# Altium schematic sheet Gameboy Link.SchDoc
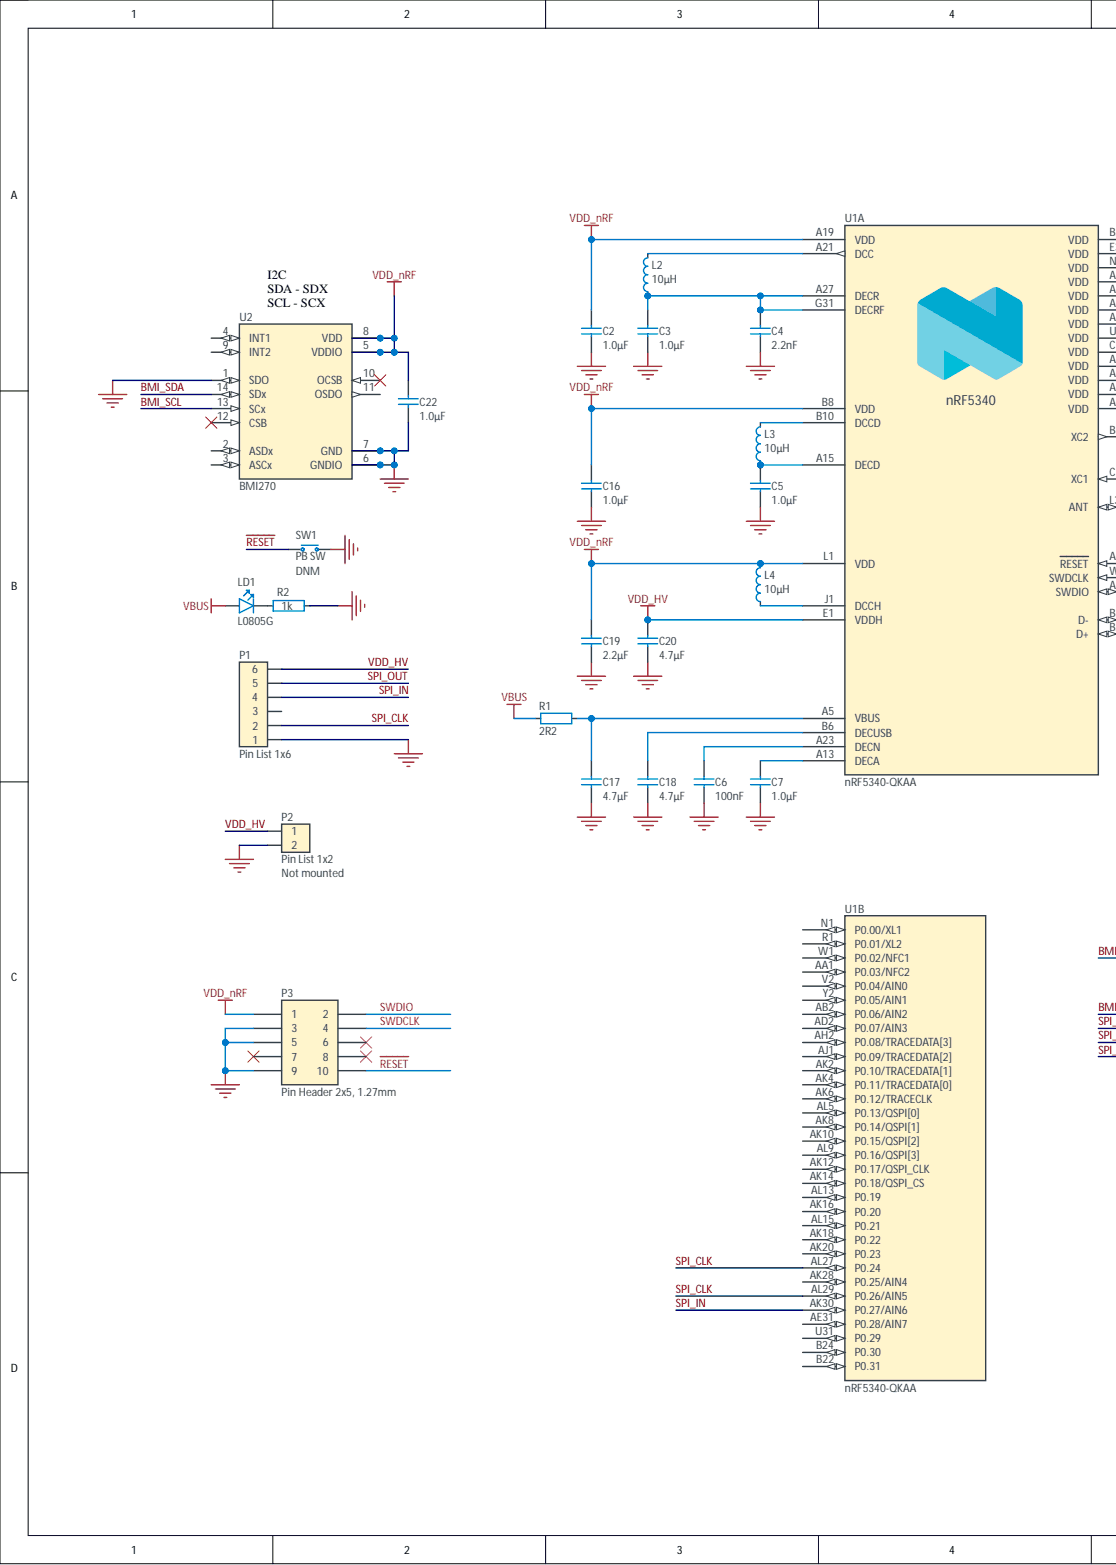
[diagram p0: Gameboy Link.SchDoc, left]
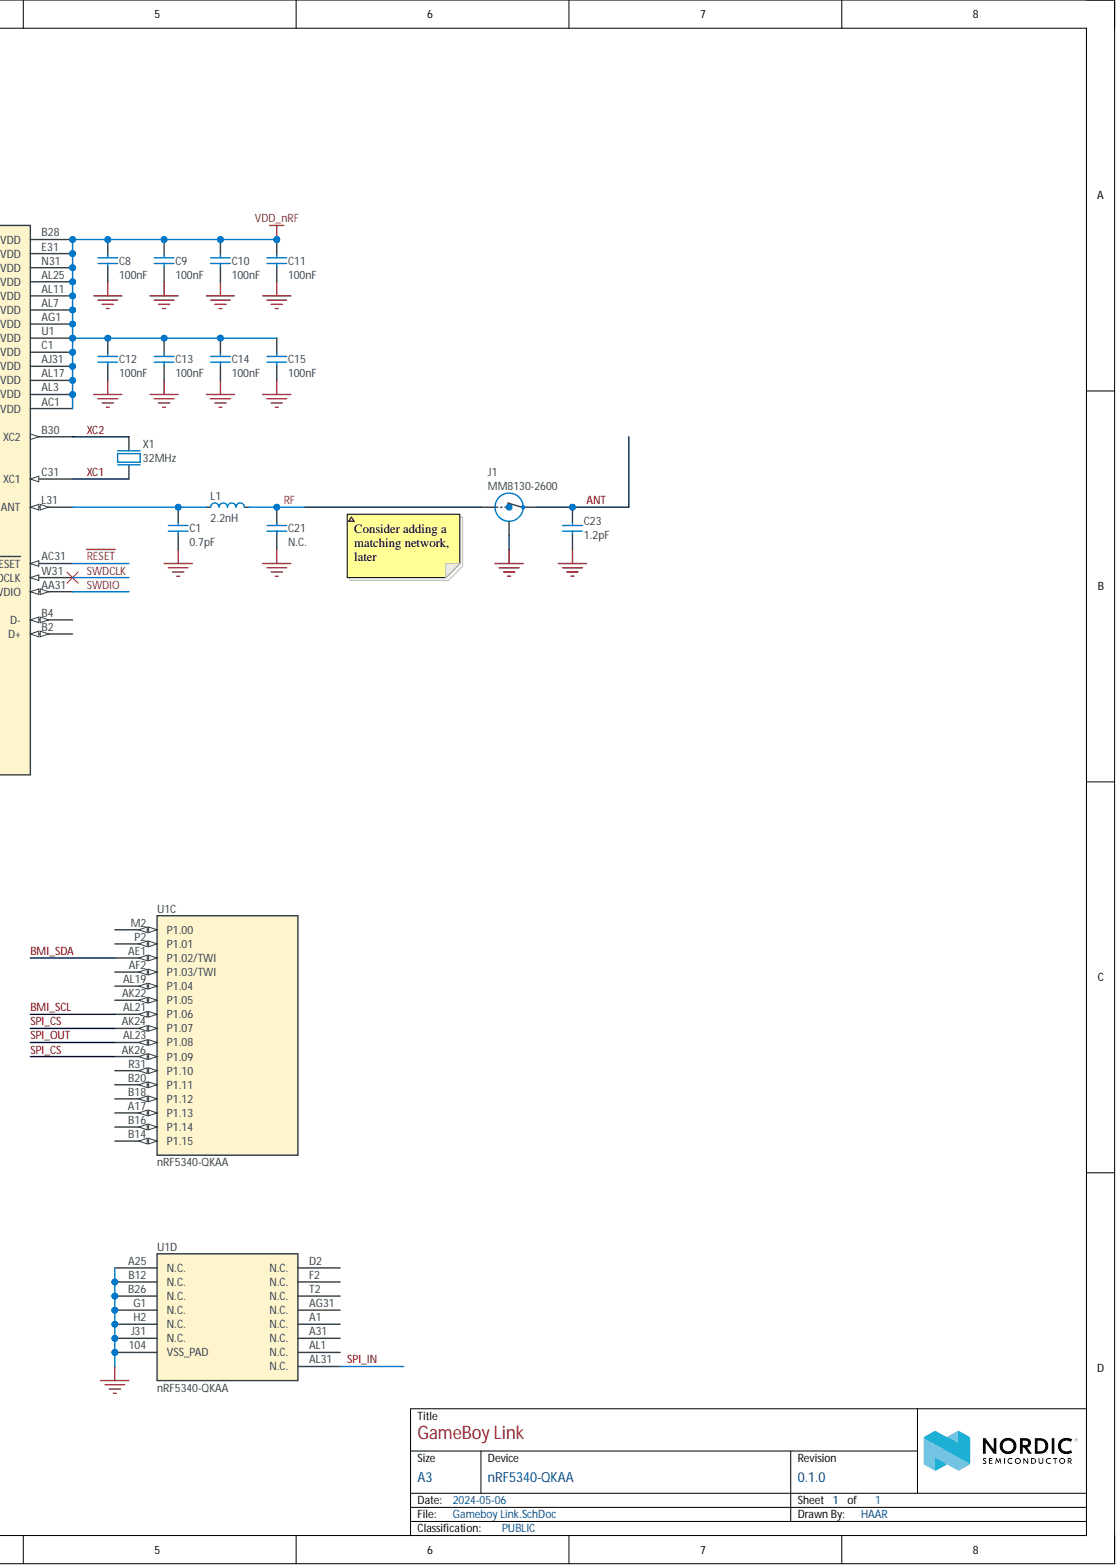
[diagram p1: Gameboy Link.SchDoc, right]

## Parts
C1  0.7pF  [CAPC0603X03L_C]
C2  1.0µF  [CAPC1005X04L]
C3  1.0µF  [CAPC1005X04L]
C4  2.2nF  [CAPC0603X03L_C]
C5  1.0µF  [CAPC1005X04L]
C6  100nF  [CAPC0603X03L_C]
C7  1.0µF  [CAPC1005X04L]
C8  100nF  [CAPC0603X03L_C]
C9  100nF  [CAPC0603X03L_C]
C10  100nF  [CAPC0603X03L_C]
C11  100nF  [CAPC0603X03L_C]
C12  100nF  [CAPC0603X03L_C]
C13  100nF  [CAPC0603X03L_C]
C14  100nF  [CAPC0603X03L_C]
C15  100nF  [CAPC0603X03L_C]
C16  1.0µF  [CAPC1005X04L]
C17  4.7µF  [CAPC1608X06L]
C18  4.7µF  [CAPC1608X06L]
C19  2.2µF  [CAPC1608X06L]
C20  4.7µF  [CAPC1608X06L]
C21  N.C.  [CAPC0603X03L_C_NC]
C22  1.0µF  [CAPC1005X04L]
C23  1.2pF  [CAPC1005X04L]
J1  MM8130-2600  [COAXIAL-SWF]
L1  2.2nH  [INDC0603X03L_C]
L2  10µH  [INDC1608X06L]
L3  10µH  [INDC1608X06L]
L4  10µH  [INDC1608X06L]
LD1  L0805G  [LED_0805_G]
P1  Pin List 1x6  [LIST_1x6]
P2  Pin List 1x2  Assembly: Not mounted  [LIST_1x2-NC]
P3  Pin Header 2x5, 1.27mm  [HDR_2x5-SMD-1.27mm-K]
R1  2R2  [RESC0603X03L_C]
R2  1k  [RESC1005X04L]
SW1  PB SW  Assembly: DNM  [Switch-PB-6.2x6.3mm-SMD]
U1  nRF5340-QKAA  [AQFN40P700X700X85_HS-99N]  (parts A,B,C,D)
U2  BMI270  [BMI270]
X1  32MHz  [BT-XTAL_2016]

## Nets
GND: C1.1 C2.2 C3.1 C4.2 C5.1 C6.2 C7.1 C8.2 C9.2 C10.2 C11.2 C12.2 C13.2 C14.2 C15.2 C16.1 C17.2 C18.2 C19.2 C20.2 C21.1 C22.2 C23.2 J1.2 P1.1 P2.2 P3.3 P3.5 P3.9 R2.1 SW1.1 U1.104(VSS_PAD) U1.A25(N.C.) U1.B12(N.C.) U1.B26(N.C.) U1.G1(N.C.) U1.H2(N.C.) U1.J31(N.C.) U2.1(SDO) U2.6(GNDIO) U2.7(GND) X1.2 X1.4
VBUS: LD1.2 R1.1
VDD_nRF: C2.1 C8.1 C9.1 C10.1 C11.1 C12.1 C13.1 C14.1 C15.1 C16.2 C19.1 C22.1 L4.2 P3.1 U1.A19(VDD) U1.AC1(VDD) U1.AG1(VDD) U1.AJ31(VDD) U1.AL3(VDD) U1.AL7(VDD) U1.AL11(VDD) U1.AL17(VDD) U1.AL25(VDD) U1.B8(VDD) U1.B28(VDD) U1.C1(VDD) U1.E31(VDD) U1.L1(VDD) U1.N31(VDD) U1.U1(VDD) U2.5(VDDIO) U2.8(VDD)
ANT: C23.1 J1.3
BMI_SCL: U1.AL21(P1.06) U2.13(SCx)
BMI_SDA: U1.AE1(P1.02/TWI) U2.14(SDx)
~{RESET}: P3.10 SW1.2 U1.AC31(RESET)
RF: C21.2 J1.1 L1.1
SPI_CLK: P1.2 U1.AL27(P0.24) U1.AL29(P0.26/AIN5)
SPI_CS: U1.AK24(P1.07) U1.AK26(P1.09)
SPI_IN: P1.4 U1.AK30(P0.27/AIN6) U1.AL31(N.C.)
SPI_OUT: P1.5 U1.AL23(P1.08)
SWDCLK: P3.4 U1.W31(SWDCLK)
SWDIO: P3.2 U1.AA31(SWDIO)
VDD_HV: C20.1 P1.6 P2.1 U1.E1(VDDH)
XC1: U1.C31(XC1) X1.1
XC2: U1.B30(XC2) X1.3
NetC1_2: C1.2 L1.2 U1.L31(ANT)
NetC3_2: C3.2 C4.1 L2.1 U1.A27(DECR) U1.G31(DECRF)
NetC5_2: C5.2 L3.1 U1.A15(DECD)
NetC6_1: C6.1 U1.A23(DECN)
NetC7_2: C7.2 U1.A13(DECA)
NetC17_1: C17.1 R1.2 U1.A5(VBUS)
NetC18_1: C18.1 U1.B6(DECUSB)
NetL2_2: L2.2 U1.A21(DCC)
NetL3_2: L3.2 U1.B10(DCCD)
NetL4_1: L4.1 U1.J1(DCCH)
NetLD1_1: LD1.1 R2.2

Not connected: P1 3; P3 6 7 8; U1 A1(N.C.) A17(P1.13) A31(N.C.) AA1(P0.03/NFC2) AB2(P0.06/AIN2) AD2(P0.07/AIN3) AE31(P0.28/AIN7) AF2(P1.03/TWI) AG31(N.C.) AH2(P0.08/TRACEDATA[3]) AJ1(P0.09/TRACEDATA[2]) AK2(P0.10/TRACEDATA[1]) AK4(P0.11/TRACEDATA[0]) AK6(P0.12/TRACECLK) AK8(P0.14/QSPI[1]) AK10(P0.15/QSPI[2]) AK12(P0.17/QSPI_CLK) AK14(P0.18/QSPI_CS) AK16(P0.20) AK18(P0.22) AK20(P0.23) AK22(P1.05) AK28(P0.25/AIN4) AL1(N.C.) AL5(P0.13/QSPI[0]) AL9(P0.16/QSPI[3]) AL13(P0.19) AL15(P0.21) AL19(P1.04) B2(D+) B4(D-) B14(P1.15) B16(P1.14) B18(P1.12) B20(P1.11) B22(P0.31) B24(P0.30) D2(N.C.) F2(N.C.) M2(P1.00) N1(P0.00/XL1) P2(P1.01) R1(P0.01/XL2) R31(P1.10) T2(N.C.) U31(P0.29) V2(P0.04/AIN0) W1(P0.02/NFC1) Y2(P0.05/AIN1); U2 2(ASDx) 3(ASCx) 4(INT1) 9(INT2) 10(OCSB) 11(OSDO) 12(CSB)

## Library data of the parts
C1 = C0201-0.7p-HQ-Murata: Capacitor, Ceramic, NP0, ±0.1pF, 25V; Manufacturer: Murata; Manufacturer Part Number: GJM0335C1ER70BB01D; Part number NEMS: 100200441; Part number Norautron: 26470001; Artikkelnr Noca: N.A.; Component Status: Active; Database Table Name: Parts$; Pad Count: 2; Component Class: Capacitors; Component Kind: Standard; ComponentLink1Description: Datasheet; ComponentLink1URL: https://search.murata.co.jp/Ceramy/image/img/A01X/G101/ENG/GJM0335C1ER70BB01-01.pdf; ComponentLink2Description: Supplier link; ComponentLink2URL: https://www.murata.com/en-eu/products/productdetail?partno=GJM0335C1ER70BB01%23; Footprint Doc: 0201; HelpURL: https://www.digikey.no/product-detail/en/murata-electronics/GJM0335C1ER70BB01D/490-8076-1-ND/4380362; LatestRevisionDate: 2020-10-13; Library Name: AltiumLib.DbLib; Note: 25V; Part Number: C0201-0.7p-HQ-Murata; Part number IPS: N.A.; PartId: 100200441; Published: 2020-03-05; Supplier 1: Digi-Key; Supplier 2: N.A.; Supplier Part Number 1: 490-8076-1-ND; Supplier Part Number 2: N.A.; Value: 0.7pF; Author: rsk
C2 C3 C5 C7 C16 C22 = C0402-1µ0-10V-X7S-GRM155C71A105KE11: Capacitor, Ceramic, 1.0µF 10V X7S 0402,±10%; Manufacturer: Murata; Part number NEMS: 100200497; Artikkelnr Noca: N.A.; Component Status: Active; Database Table Name: Parts$; Pad Count: 2; Component Class: Capacitors; Component Kind: Standard; ComponentLink1Description: Datasheet; ComponentLink1URL: https://www.murata.com/en-sg/products/productdetail?partno=GRM155C71A105KE11%23; ComponentLink2Description: Supplier link; ComponentLink2URL: https://www.digikey.com/en/products/detail/murata-electronics/GRM155C71A105KE11D/6606086; Footprint Doc: 0402; HelpURL: N.A.; LatestRevisionDate: 2021-12-09; Library Name: AltiumLib.DbLib; Manufacturer Part Number: GRM155C71A105KE11; Note: 10V; Part Number: C0402-1µ0-10V-X7S-GRM155C71A105KE11; Part number IPS: N.A.; Part number Norautron: N.A.; PartId: 100200497; Published: 2021-12-09; Supplier 1: Mouser; Supplier 2: Digi-Key; Supplier Part Number 1: 81-GRM155C71A105KE1J; Supplier Part Number 2: 490-14457-1-ND; Value: 1.0µF; Author: prbu
C4 = C0201-2n2: Capacitor, X7R, ±10%, 10V; Part number IPS: 00000001778; Part number NEMS: 100200060; Part number Norautron: 26470002; Artikkelnr Noca: N.A.; Component Status: Active; Database Table Name: Parts$; Pad Count: 2; Component Class: Capacitors; Component Kind: Standard; ComponentLink1Description: Datasheet; ComponentLink1URL: N.A.; ComponentLink2Description: Supplier link; ComponentLink2URL: N.A.; Footprint Doc: 0201; HelpURL: N.A.; LatestRevisionDate: 2014-11-13; Library Name: AltiumLib.DbLib; Manufacturer: N.A.; Manufacturer Part Number: N.A.; Note: 10V; Part Number: C0201-2n2; PartId: 100200060; Published: 2014-11-13; Supplier 1: N.A.; Supplier 2: N.A.; Supplier Part Number 1: N.A.; Supplier Part Number 2: N.A.; Value: 2.2nF; Author: rsk
C6 C8-C15 = C0201-100n-16V-X7S: Capacitor, X7S, 16V; Manufacturer: Murata; Manufacturer Part Number: GRM033C71C104KE14D; Part number NEMS: 100200403; Artikkelnr Noca: N.A.; Component Status: Active; Database Table Name: Parts$; Pad Count: 2; Component Class: Capacitors; Component Kind: Standard; ComponentLink1Description: Datasheet; ComponentLink1URL: http://search.murata.co.jp/Ceramy/image/img/A01X/G101/ENG/GRM033C71C104KE14-01.pdf; ComponentLink2Description: Supplier link; ComponentLink2URL: N.A.; Footprint Doc: 0201; HelpURL: N.A.; LatestRevisionDate: 2020-10-13; Library Name: AltiumLib.DbLib; Note: 16V; Part Number: C0201-100n-16V-X7S; Part number IPS: N.A.; Part number Norautron: N.A.; PartId: 100200403; Published: 2018-05-07; Supplier 1: Digi-Key; Supplier 2: N.A.; Supplier Part Number 1: 490-10387-1-ND; Supplier Part Number 2: N.A.; Value: 100nF; Author: rsk
C17 C20 = C0603-4µ7-10V-X7S: Capacitor, X7S, ±10%, 10V; Manufacturer: N.A.; Part number IPS: 00000001779; Part number NEMS: 100200351; Artikkelnr Noca: 20030013; Component Status: Active; Database Table Name: Parts$; Pad Count: 2; Component Class: Capacitors; Component Kind: Standard; ComponentLink1Description: Datasheet; ComponentLink1URL: N.A.; ComponentLink2Description: Supplier link; ComponentLink2URL: N.A.; Footprint Doc: 0603; HelpURL: N.A.; LatestRevisionDate: 2016-08-15; Library Name: AltiumLib.DbLib; Manufacturer Part Number: N.A.; Note: 10V; Part Number: C0603-4µ7-10V-X7S; Part number Norautron: N.A.; PartId: 100200351; Published: 2016-08-15; Supplier 1: Digi-Key; Supplier 2: N.A.; Supplier Part Number 1: 445-14258-1-ND; Supplier Part Number 2: N.A.; Value: 4.7µF; Author: rsk
C18 = C0603-4µ7-6.3V-X7R: Capacitor, X7R, ±10%, 6.3V; Manufacturer: Samsung Electro-Mechanics America; Manufacturer Part Number: CL10B475KQ8NQNC; Part number IPS: 00000002541; Part number NEMS: 100200350; Part number Norautron: N.A.; Artikkelnr Noca: 20039473; Component Status: Active; Database Table Name: Parts$; Pad Count: 2; Component Class: Capacitors; Component Kind: Standard; ComponentLink1Description: Datasheet; ComponentLink1URL: N.A.; ComponentLink2Description: Supplier link; ComponentLink2URL: N.A.; Footprint Doc: 0603; HelpURL: http://media.digikey.com/pdf/Data%20Sheets/Samsung%20PDFs/CL10B475KQ8NQNC_Spec.pdf; LatestRevisionDate: 2015-06-10; Library Name: AltiumLib.DbLib; Note: 6.3V; Part Number: C0603-4µ7-6.3V-X7R; PartId: 100200350; Published: 2015-06-10; Supplier 1: Digi-Key; Supplier 2: N.A.; Supplier Part Number 1: 1276-2087-1-ND; Supplier Part Number 2: N.A.; Value: 4.7µF; Author: rsk
C19 = C0603-2µ2-6.3V-X7R: Capacitor, X7R, ±10%; Manufacturer: N.A.; Part number NEMS: 100200272; Artikkelnr Noca: 20039322; Component Status: Active; Database Table Name: Parts$; Note: 6.3V; Pad Count: 2; Component Class: Capacitors; Component Kind: Standard; ComponentLink1Description: Datasheet; ComponentLink1URL: N.A.; ComponentLink2Description: Supplier link; ComponentLink2URL: N.A.; Footprint Doc: 0603; HelpURL: N.A.; LatestRevisionDate: 2021-07-22; Library Name: AltiumLib.DbLib; Manufacturer Part Number: N.A.; Part Number: C0603-2µ2-6.3V-X7R; Part number IPS: N.A.; Part number Norautron: N.A.; PartId: 100200272; Published: 2009-05-08; Supplier 1: N.A.; Supplier 2: N.A.; Supplier Part Number 1: N.A.; Supplier Part Number 2: N.A.; Value: 2.2µF; Author: rsk
C21 = C0201-N.C.: Not mounted; Assembly: Not mounted; Database Table Name: Parts$; Pad Count: 2; Component Class: Capacitors; Component Kind: Standard; Footprint Doc: 0201; Library Name: AltiumLib.DbLib; Value: N.C.
C23 = C0402-1.2p: Capacitor, NP0, ±0.1pF; Manufacturer: N.A.; Part number IPS: 00000005410; Part number NEMS: 100200119; Artikkelnr Noca: 20029157; Component Status: Active; Database Table Name: Parts$; Note: 50V; Pad Count: 2; Component Class: Capacitors; Component Kind: Standard; ComponentLink1Description: Datasheet; ComponentLink1URL: N.A.; ComponentLink2Description: Supplier link; ComponentLink2URL: N.A.; Footprint Doc: 0402; HelpURL: N.A.; LatestRevisionDate: 2011-05-11; Library Name: AltiumLib.DbLib; Manufacturer Part Number: N.A.; Part Number: C0402-1.2p; Part number Norautron: N.A.; PartId: 100200119; Published: 2009-05-08; Supplier 1: N.A.; Supplier 2: N.A.; Supplier Part Number 1: N.A.; Supplier Part Number 2: N.A.; Value: 1.2pF; Author: rsk
J1 = MM8130-2600: Coaxial Connector with Switch; Manufacturer: Murata; Part number IPS: 00000009955; Part number NEMS: 100500400; Part number Norautron: 26030338; Artikkelnr Noca: 55029011; Component Status: Active; Database Table Name: Parts$; Note: N.A.; Pad Count: 3; Component Class: Connectors; Component Kind: Standard; ComponentLink1Description: Datasheet; ComponentLink1URL: N.A.; ComponentLink2Description: Supplier link; ComponentLink2URL: N.A.; Footprint Doc: COAXIAL-SWF; HelpURL: http://www.murata.com/products/catalog/pdf/o33e.pdf; LatestRevisionDate: 2014-04-24; Library Name: AltiumLib.DbLib; Manufacturer Part Number: MM8130-2600; Part Number: MM8130-2600; PartId: 100500400; Published: 2014-04-24; Supplier 1: Digi-Key; Supplier 2: Mouser; Supplier Part Number 1: 490-4981-1-ND; Supplier Part Number 2: 81-MM8130-2600RA2; System: Connector; Value: MM8130-2600; Author: rsk
L1 = L0201-2n2-HQ-Murata: Inductor, 600mA, ±0.1ｎH, 120mOhm; Manufacturer: Murata; Manufacturer Part Number: LQP03HQ2N2B02; Part number NEMS: 100300209; Part number Norautron: 26521773; Artikkelnr Noca: N.A.; Component Status: Active; Database Table Name: Parts$; Note: -55°C ~ 125°C; Pad Count: 2; Component Class: Inductors; Component Kind: Standard; ComponentLink1Description: Datasheet; ComponentLink1URL: https://search.murata.co.jp/Ceramy/image/img/P02/JELF243C-0021.pdf; ComponentLink2Description: Supplier link; ComponentLink2URL: N.A.; Footprint Doc: 0201; HelpURL: https://psearch.en.murata.com/inductor/product/LQP03HQ2N2B02%23.html; LatestRevisionDate: 2018-10-23; Library Name: AltiumLib.DbLib; Part Number: L0201-2n2-HQ-Murata; Part number IPS: N.A.; PartId: 100300209; Published: 2018-10-23; Supplier 1: Digi-Key; Supplier 2: N.A.; Supplier Part Number 1: 490-13899-1-ND; Supplier Part Number 2: N.A.; Value: 2.2nH; Author: rsk
L2-L4 = L0603-10µ-80mA-360mΩ-LBMF1608T100K (NRND): Inductor, 80mA, ±10%; Manufacturer: Taiyo Yuden; Part number IPS: 00000007794; Part number NEMS: 100300078; Part number Norautron: N.A.; Artikkelnr Noca: 33029314; Component Status: NRND; Database Table Name: Parts$; Note: LBMF1608T100K 10µH 80mA 0603; Pad Count: 2; Component Class: Inductors; Component Kind: Standard; ComponentLink1Description: Datasheet; ComponentLink1URL: N.A.; ComponentLink2Description: Supplier link; ComponentLink2URL: N.A.; Footprint Doc: 0603; HelpURL: http://www.yuden.co.jp/ut/product/pdf/elb2_e.pdf; LatestRevisionDate: 2023-09-11; Library Name: AltiumLib.DbLib; Manufacturer Part Number: LBMF1608T100K; Part Number: L0603-10µ-80mA-360mΩ-LBMF1608T100K (NRND); PartId: 100300078; Published: 2010-02-24; Supplier 1: Digi-Key; Supplier 2: Farnell; Supplier Part Number 1: 587-1714-1-ND; Supplier Part Number 2: 178-6105; Value: 10µH; Author: rsk
LD1 = SML-210PT: LED, SMD, 0805, GREEN; Manufacturer: Rohm Semiconductor; Manufacturer Part Number: SML-210PTT86; Part number NEMS: 101400017; Artikkelnr Noca: N.A.; Component Status: Active; Database Table Name: Parts$; Note: 4 mcd @ 20 mA; Pad Count: 2; Component Class: Optoelectronics; Component Kind: Standard; ComponentLink1Description: Datasheet; ComponentLink1URL: N.A.; ComponentLink2Description: Supplier link; ComponentLink2URL: N.A.; Footprint Doc: 0805; HelpURL: http://no.farnell.com/rohm/sml-210dtt86/led-smd-0805-orange/dp/1685051; LatestRevisionDate: 2009-09-03; Library Name: AltiumLib.DbLib; Part Number: SML-210PT; Part number IPS: N.A.; Part number Norautron: N.A.; PartId: 101400017; Published: 2009-09-03; Supplier 1: N.A.; Supplier 2: N.A.; Supplier Part Number 1: N.A.; Supplier Part Number 2: N.A.; Value: L0805G; Author: rsk
P1 = Pin List 1x6: Pin List 1x6, 2.54mm (100mil); Manufacturer: N.A.; Part number NEMS: 100500318; Artikkelnr Noca: 56019043; Component Status: Active; Database Table Name: Parts$; Pad Count: 6; System: Connector; Component Class: Connectors; Component Kind: Standard; ComponentLink1Description: Datasheet; ComponentLink1URL: N.A.; ComponentLink2Description: Supplier link; ComponentLink2URL: N.A.; Footprint Doc: LIST_1x6; HelpURL: N.A.; LatestRevisionDate: 2009-12-12; Library Name: AltiumLib.DbLib; Manufacturer Part Number: N.A.; Note: N.A.; Part Number: Pin List 1x6; Part number IPS: N.A.; Part number Norautron: N.A.; PartId: 100500318; Published: 2009-12-12; Supplier 1: N.A.; Supplier 2: N.A.; Supplier Part Number 1: N.A.; Supplier Part Number 2: N.A.; Value: Pin List 1x6; Author: rsk
P2 = Pin List 1x2, N.C.: Pin List 1x2, 2.54mm (100mil); Part number NEMS: 100500298; Artikkelnr Noca: N.A.; Component Status: Active; Database Table Name: Parts$; Pad Count: 2; System: Connector; Component Class: Connectors; Component Kind: Standard; ComponentLink1Description: Datasheet; ComponentLink1URL: N.A.; ComponentLink2Description: Supplier link; ComponentLink2URL: N.A.; Footprint Doc: LIST_1x2; HelpURL: N.A.; LatestRevisionDate: 2017-02-02; Library Name: AltiumLib.DbLib; Manufacturer: N.A.; Manufacturer Part Number: N.A.; Note: N.A.; Part Number: Pin List 1x2, N.C.; Part number IPS: N.A.; Part number Norautron: N.A.; PartId: 100500298; Published: 2017-01-30; Supplier 1: N.A.; Supplier 2: N.A.; Supplier Part Number 1: N.A.; Supplier Part Number 2: N.A.; Value: Pin List 1x2; Author: rsk
P3 = Pin Header 2x5 SMD, 1.27mm, KS: Pin Header 2x5, 1.27mm (50mil), SMD, Keying Shroud; Manufacturer: WCON; Manufacturer Part Number: 3132-10MS0BK00R5; Part number NEMS: 100500261; Artikkelnr Noca: N.A.; Component Status: Active; Database Table Name: Parts$; Note: (Replaced 3132-10MS0BK00R1); Pad Count: 10; System: Connector; Component Class: Connectors; Component Kind: Standard; ComponentLink1Description: Datasheet; ComponentLink1URL: N.A.; ComponentLink2Description: Supplier link; ComponentLink2URL: N.A.; Footprint Doc: HDR_2x5-SMD-1.27mm; HelpURL: \\hizz\pro\app9717\Datasheet\connectors\W-CON\3132-XXMXXBK00XX.pdf; LatestRevisionDate: 2024-02-02; Library Name: AltiumLib.DbLib; Part Number: Pin Header 2x5 SMD, 1.27mm, KS; Part number IPS: N.A.; Part number Norautron: N.A.; PartId: 100500261; Published: 2016-01-22; Supplier 1: Cabcon; Supplier 2: N.A.; Supplier Part Number 1: 3132-10MS0BK00R5; Supplier Part Number 2: N.A.; Value: Pin Header 2x5, 1.27mm; Author: rsk
R1 = R0201-2R2: Resistor, ±1%, 0.05W; Part number NEMS: 100100006; Part number Norautron: 26470029; Artikkelnr Noca: N.A.; Component Status: Active; Database Table Name: Parts$; Note: Chip Res 1%; Pad Count: 2; Component Class: Resistors; Component Kind: Standard; ComponentLink1Description: Datasheet; ComponentLink1URL: N.A.; ComponentLink2Description: Supplier link; ComponentLink2URL: N.A.; Footprint Doc: 0201; HelpURL: N.A.; LatestRevisionDate: 2014-11-13; Library Name: AltiumLib.DbLib; Manufacturer: N.A.; Manufacturer Part Number: N.A.; Part Number: R0201-2R2; Part number IPS: N.A.; PartId: 100100006; Published: 2014-11-13; Supplier 1: N.A.; Supplier 2: N.A.; Supplier Part Number 1: N.A.; Supplier Part Number 2: N.A.; Value: 2R2; Author: rsk
R2 = R0402-1k0: Resistor, ±1%, 0.063W; Manufacturer: N.A.; Part number IPS: 00000002218; Part number NEMS: 100100171; Artikkelnr Noca: 27049073; Component Status: Active; Database Table Name: Parts$; Note: Chip Res 1%; Pad Count: 2; Component Class: Resistors; Component Kind: Standard; ComponentLink1Description: Datasheet; ComponentLink1URL: N.A.; ComponentLink2Description: Supplier link; ComponentLink2URL: N.A.; Footprint Doc: 0402; HelpURL: N.A.; LatestRevisionDate: 2009-11-23; Library Name: AltiumLib.DbLib; Manufacturer Part Number: N.A.; Part Number: R0402-1k0; Part number Norautron: N.A.; PartId: 100100171; Published: 2009-05-08; Supplier 1: Farnell; Supplier 2: N.A.; Supplier Part Number 1: 173-8850; Supplier Part Number 2: N.A.; Value: 1k; Author: rsk
SW1 = Switch-PB-6.2x6.3mm-SMD: Tactile Switch, SPNO, SMD, 160gf, 6.2x6.3x2.5mm; Manufacturer: Dailywell; Manufacturer Part Number: TS604VM1-025BR-R; Part number IPS: 00000007812; Part number NEMS: 101500001, 101500133; Artikkelnr Noca: 50019106; Component Status: Active; Database Table Name: Parts$; Note: N.A.; Pad Count: 4; Component Class: Switches; Component Kind: Standard; ComponentLink1Description: Datasheet; ComponentLink1URL: N.A.; ComponentLink2Description: Supplier link; ComponentLink2URL: N.A.; Footprint Doc: Switch-PB-6.2x6.3mm-SMD; HelpURL: \\hizz\pro\app9717\Datasheet\switches\Dailywell\TS604VM1-025BR-R.pdf; LatestRevisionDate: 2016-04-21; Library Name: AltiumLib.DbLib; Part Number: Switch-PB-6.2x6.3mm-SMD; Part number Norautron: N.A.; PartId: 101500001; Published: 2010-02-01; Supplier 1: Cabcon; Supplier 2: Mouser; Supplier Part Number 1: TS604VM1-025BR-R; Supplier Part Number 2: 688-SKHUALE010; Value: PB SW; Author: rsk
U1 = nRF5340-QKAA: Multi-protocol Bluetooth Low Energy, IEEE 802.15.4, ANT and 2.4GHz proprietary system-on-chip; Manufacturer: Nordic Semiconductor; Part number NEMS: 102000153; Artikkelnr Noca: N.A.; Component Status: Active; Database Table Name: Parts$; Pad Count: 98; Component Class: Nordic_Devices; Component Kind: Standard; ComponentLink1Description: Datasheet; ComponentLink1URL: N.A.; ComponentLink2Description: Supplier link; ComponentLink2URL: N.A.; Footprint Doc: AQFN-98; HelpURL: N.A.; LatestRevisionDate: 2019-03-28; Library Name: AltiumLib.DbLib; Manufacturer Part Number: nRF5340-QKAA; Note: N.A.; Part Number: nRF5340-QKAA; Part number IPS: N.A.; Part number Norautron: N.A.; PartId: 102000153; Published: 2017-01-09; Supplier 1: Nordic Semiconductor; Supplier 2: N.A.; Supplier Part Number 1: nRF5340-QKAA; Supplier Part Number 2: N.A.; Value: nRF5340-QKAA; Author: rsk
U2 = BMI270: 6-axis, smart, low-power, inertial measurement unit; Manufacturer: Bosch; Part number NEMS: 101900620; Artikkelnr Noca: N.A.; Component Status: Active; Database Table Name: Parts$; Pad Count: 14; Component Class: Misc_ICs; Component Kind: Standard; ComponentLink1Description: Datasheet; ComponentLink1URL: https://www.bosch-sensortec.com/media/boschsensortec/downloads/datasheets/bst-bmi270-ds000.pdf; ComponentLink2Description: Supplier link; ComponentLink2URL: https://www.bosch-sensortec.com/products/motion-sensors/imus/bmi270.html; Footprint Doc: LGA-14; HelpURL: https://www.bosch-sensortec.com/media/boschsensortec/downloads/datasheets/bst-bmi270-ds000.pdf; LatestRevisionDate: 2021-08-03; Library Name: AltiumLib.DbLib; Manufacturer Part Number: BMI270; Note: N.A.; Part Number: BMI270; Part number IPS: N.A.; Part number Norautron: N.A.; PartId: 101900620; Published: 2020-07-07; Supplier 1: Digi-Key; Supplier 2: Mouser; Supplier Part Number 1: 828-1091-1-ND; Supplier Part Number 2: 262-BMI270; Value: BMI270; Author: rsk
X1 = XTAL_SMD2016_32MHz_8pF_Golledge: XTAL SMD 2016, 32MHz, Cl=8pF, Tol: ±10ppm, Stab: ±15ppm; Manufacturer Part Number: MP06003; Part number IPS: 00000001431; Part number NEMS: 101600041; Part number Norautron: N.A.; Artikkelnr Noca: 22010050; Component Status: Active; Database Table Name: Parts$; Note: XTAL SMD 32.000MHz; Pad Count: 4; Component Class: Oscillators; Component Kind: Standard; ComponentLink1Description: Datasheet; ComponentLink1URL: N.A.; ComponentLink2Description: Supplier link; ComponentLink2URL: N.A.; Footprint Doc: XTAL_2016; HelpURL: \\hizz\pro\app9717\Datasheet\crystals\Golledge\mp06003.pdf; LatestRevisionDate: 2016-11-02; Library Name: AltiumLib.DbLib; Manufacturer: Golledge; Part Number: XTAL_SMD2016_32MHz_8pF_Golledge; PartId: 101600041; Published: 2016-11-02; Supplier 1: Link Nordic; Supplier 2: N.A.; Supplier Part Number 1: N.A.; Supplier Part Number 2: N.A.; Value: 32MHz; Author: rsk
